# Altium schematic sheet [10] CAM_DISP.SchDoc("[10] CAM_DISP")
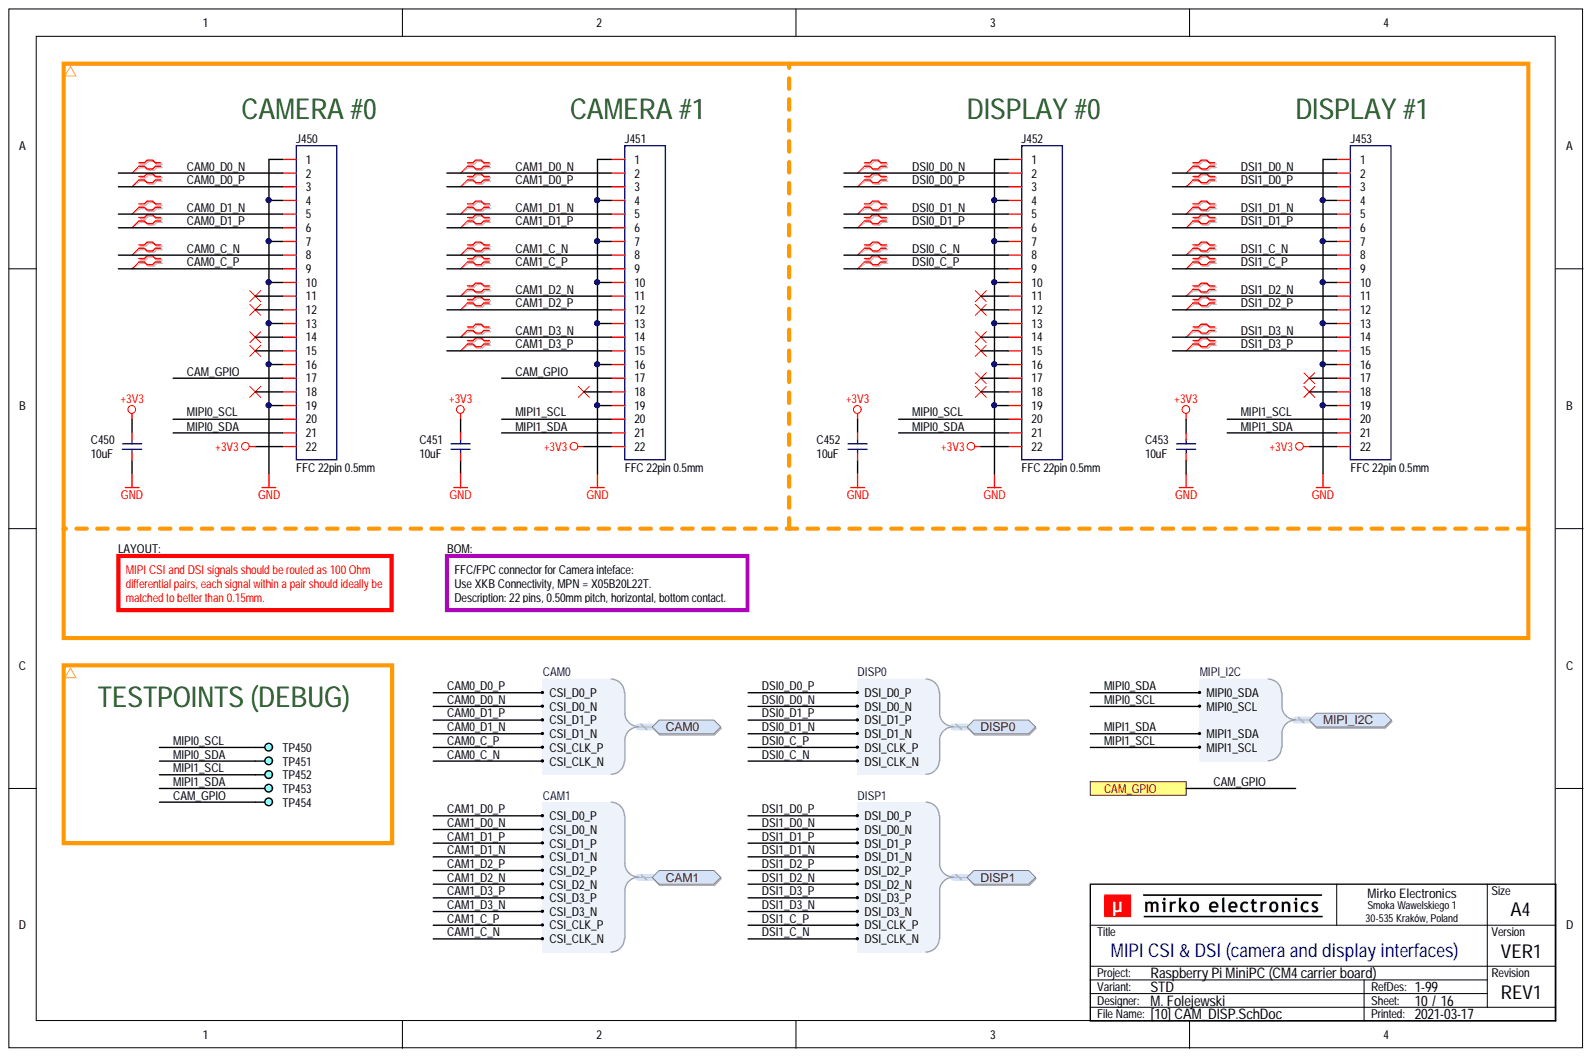
[diagram p0: [10] CAM_DISP.SchDoc]

## Parts
C450  10uF  [CAP_0603_R]
C451  10uF  [CAP_0603_R]
C452  10uF  [CAP_0603_R]
C453  10uF  [CAP_0603_R]
J450  FFC 22pin 0.5mm  Pitch: 0.5mm  [CON_XKB_X05B20L22T_REV]
J451  FFC 22pin 0.5mm  Pitch: 0.5mm  [CON_XKB_X05B20L22T_REV]
J452  FFC 22pin 0.5mm  Pitch: 0.5mm  [CON_XKB_X05B20L22T_REV]
J453  FFC 22pin 0.5mm  Pitch: 0.5mm  [CON_XKB_X05B20L22T_REV]
TP450  DEBUG  [PCB_TP_DBG_50]  (no BOM)
TP451  DEBUG  [PCB_TP_DBG_50]  (value not drawn)  (no BOM)
TP452  DEBUG  [PCB_TP_DBG_50]  (value not drawn)  (no BOM)
TP453  DEBUG  [PCB_TP_DBG_50]  (value not drawn)  (no BOM)
TP454  DEBUG  [PCB_TP_DBG_50]  (value not drawn)  (no BOM)

## Ports
CAM0, CAM0.CSI_CLK_N, CAM0.CSI_CLK_P, CAM0.CSI_D0_N, CAM0.CSI_D0_P, CAM0.CSI_D1_N, CAM0.CSI_D1_P, CAM1, CAM1.CSI_CLK_N, CAM1.CSI_CLK_P, CAM1.CSI_D0_N, CAM1.CSI_D0_P, CAM1.CSI_D1_N, CAM1.CSI_D1_P, CAM1.CSI_D2_N, CAM1.CSI_D2_P, CAM1.CSI_D3_N, CAM1.CSI_D3_P, CAM_GPIO, DISP0, DISP0.DSI_CLK_N, DISP0.DSI_CLK_P, DISP0.DSI_D0_N, DISP0.DSI_D0_P, DISP0.DSI_D1_N, DISP0.DSI_D1_P, DISP1, DISP1.DSI_CLK_N, DISP1.DSI_CLK_P, DISP1.DSI_D0_N, DISP1.DSI_D0_P, DISP1.DSI_D1_N, DISP1.DSI_D1_P, DISP1.DSI_D2_N, DISP1.DSI_D2_P, DISP1.DSI_D3_N, DISP1.DSI_D3_P, MIPI_I2C, MIPI_I2C.MIPI0_SCL, MIPI_I2C.MIPI0_SDA, MIPI_I2C.MIPI1_SCL, MIPI_I2C.MIPI1_SDA

## Nets
+3V3: C450.2 C451.2 C452.2 C453.2 J450.22 J451.22 J452.22 J453.22
GND: C450.1 C451.1 C452.1 C453.1 J450.1 J450.4 J450.7 J450.10 J450.13 J450.16 J450.19 J451.1 J451.4 J451.7 J451.10 J451.13 J451.16 J451.19 J452.1 J452.4 J452.7 J452.10 J452.13 J452.16 J452.19 J453.1 J453.4 J453.7 J453.10 J453.13 J453.16 J453.19
CAM0_C_N: J450.8 ; port CAM0
CAM0_C_P: J450.9 ; port CAM0
CAM0_D0_N: J450.2 ; port CAM0
CAM0_D0_P: J450.3 ; port CAM0
CAM0_D1_N: J450.5 ; port CAM0
CAM0_D1_P: J450.6 ; port CAM0
CAM1_C_N: J451.8 ; port CAM1
CAM1_C_P: J451.9 ; port CAM1
CAM1_D0_N: J451.2 ; port CAM1
CAM1_D0_P: J451.3 ; port CAM1
CAM1_D1_N: J451.5 ; port CAM1
CAM1_D1_P: J451.6 ; port CAM1
CAM1_D2_N: J451.11 ; port CAM1
CAM1_D2_P: J451.12 ; port CAM1
CAM1_D3_N: J451.14 ; port CAM1
CAM1_D3_P: J451.15 ; port CAM1
CAM_GPIO: J450.17 J451.17 TP454.1 ; port CAM_GPIO
DSI0_C_N: J452.8 ; port DISP0
DSI0_C_P: J452.9 ; port DISP0
DSI0_D0_N: J452.2 ; port DISP0
DSI0_D0_P: J452.3 ; port DISP0
DSI0_D1_N: J452.5 ; port DISP0
DSI0_D1_P: J452.6 ; port DISP0
DSI1_C_N: J453.8 ; port DISP1
DSI1_C_P: J453.9 ; port DISP1
DSI1_D0_N: J453.2 ; port DISP1
DSI1_D0_P: J453.3 ; port DISP1
DSI1_D1_N: J453.5 ; port DISP1
DSI1_D1_P: J453.6 ; port DISP1
DSI1_D2_N: J453.11 ; port DISP1
DSI1_D2_P: J453.12 ; port DISP1
DSI1_D3_N: J453.14 ; port DISP1
DSI1_D3_P: J453.15 ; port DISP1
MIPI0_SCL: J450.20 J452.20 TP450.1 ; port MIPI_I2C
MIPI0_SDA: J450.21 J452.21 TP451.1 ; port MIPI_I2C
MIPI1_SCL: J451.20 J453.20 TP452.1 ; port MIPI_I2C
MIPI1_SDA: J451.21 J453.21 TP453.1 ; port MIPI_I2C

Not connected: J450 11 12 14 15 18; J451 18; J452 11 12 14 15 17 18; J453 17 18

## Library data of the parts
C450-C453 = CAP-0023: Capacitor; MLCC; GENERIC; TBD; TBD; TBD; TBD; SMD; 0603 (1608);; Database Table Name: CAPACITOR$; Voltage Rating: TBD; Value: 10uF; Tolerance: TBD; ROHS Status: TBD; Packaging Type: TBD; Package (Case): 0603 (1608); Operating Temperature: TBD; MPN: GENERIC; Mounting Type: SMD; Manufacturer: GENERIC; ID: CAP-0023; Features: TBD; Dielectric: TBD; Component Type: Capacitor; Component Subtype: MLCC; Component Kind: Standard; Component Height: TBD; Component Body: TBD; Capacitance: GENERIC; Library Name: MirFol_db_local.DbLib
J450-J453 = CON-0004: Connector; FFC/FPC; 1 x 22 pins; 22pin; 0.5mm; TBD; TBD; TBD; SMD; FFC/FPC;; MPN: X05B20L22T; Manufacturer: XKB Connectivity; Database Table Name: CONNECTOR$; Value: FFC 22pin 0.5mm; ROHS Status: TBD; Pin Count: 22pin; Packaging Type: TBD; Package: FFC/FPC; Orientation: TBD; Operating Temperature: TBD; Mounting Type: SMD; ID: CON-0004; Features: TBD; Contact Rating: TBD; Contact Plating: TBD; Contact Gender: TBD; Configuration: 1 x 22 pins; Component Type: Connector; Component Subtype: FFC/FPC; Component Kind: Standard; Component Height: TBD; Component Body: TBD; Library Name: MirFol_db_local.DbLib
TP450-TP454 = PCB_TP_DBG: PCB Marking; Test Point (Debug);; Value: DEBUG; Library Name: MirFol_lib.SchLib
